# Altium schematic sheet Filter_Protection.SchDoc(Filter_Protection)
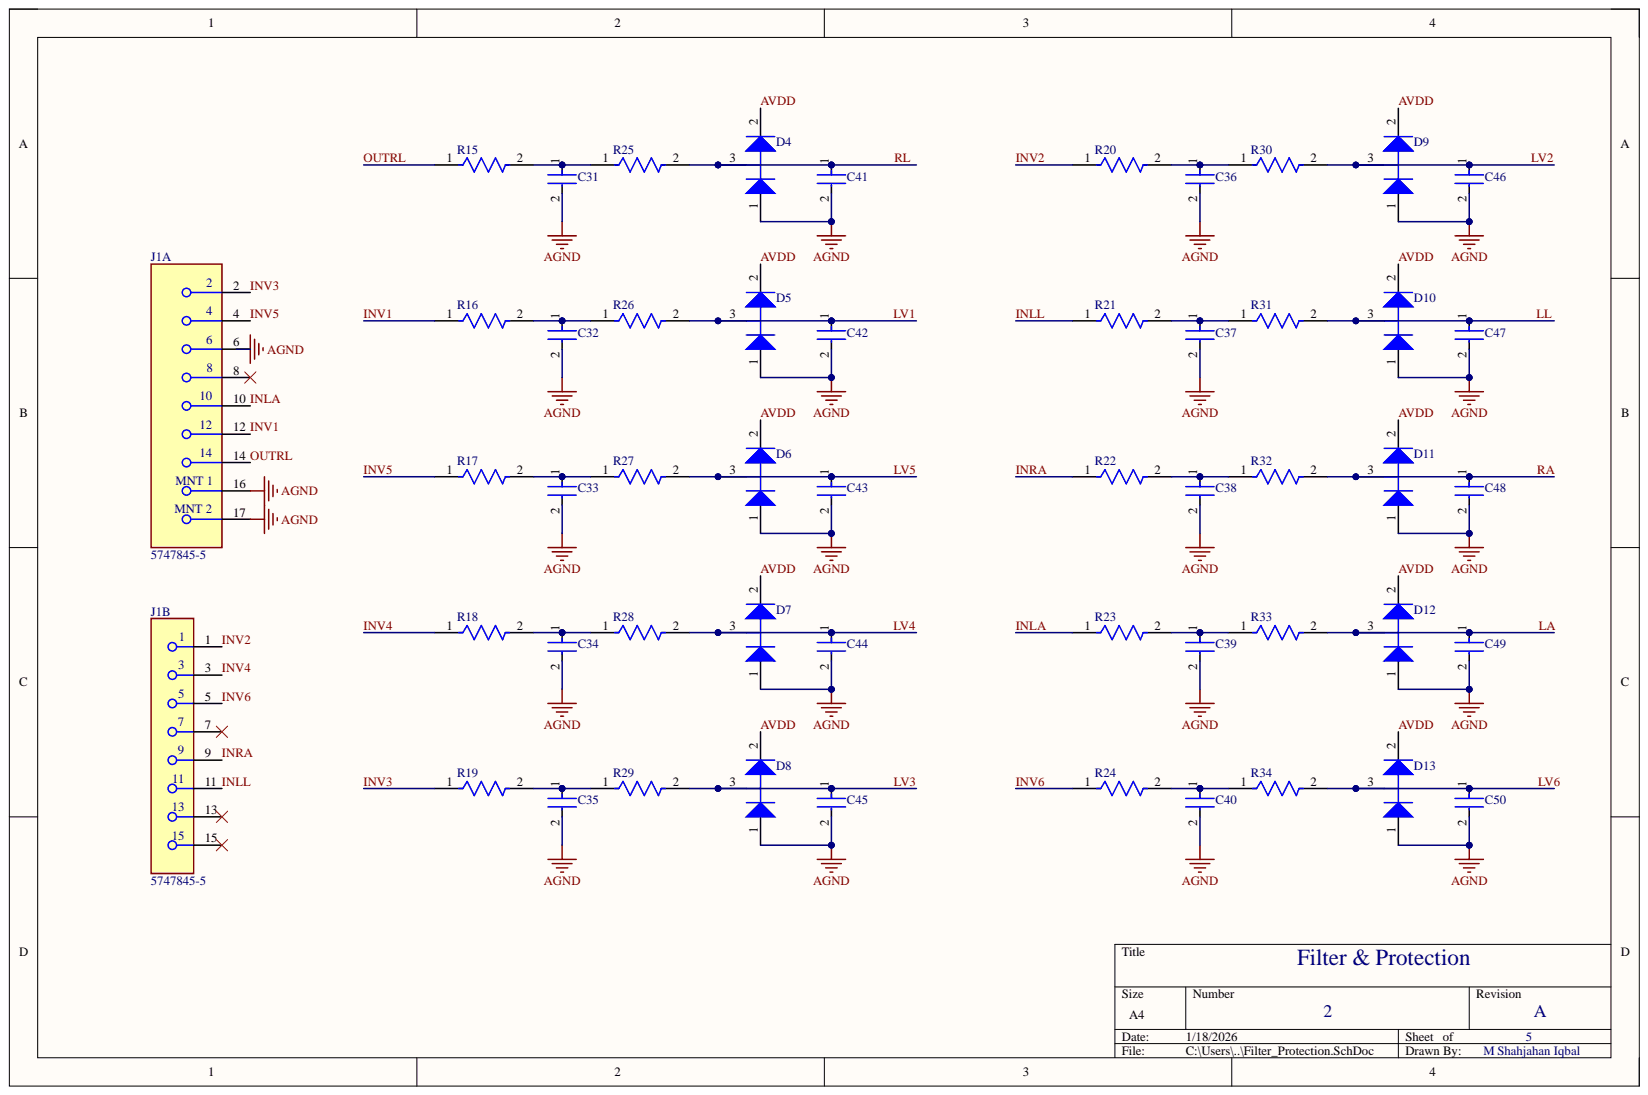
[diagram p0: Filter_Protection.SchDoc]

## Parts
C31  C0603C470K8GACTU  [FP-C0603C-CF-MFG]  (value not drawn)
C32  C0603C470K8GACTU  [FP-C0603C-CF-MFG]  (value not drawn)
C33  C0603C470K8GACTU  [FP-C0603C-CF-MFG]  (value not drawn)
C34  C0603C470K8GACTU  [FP-C0603C-CF-MFG]  (value not drawn)
C35  C0603C470K8GACTU  [FP-C0603C-CF-MFG]  (value not drawn)
C36  C0603C470K8GACTU  [FP-C0603C-CF-MFG]  (value not drawn)
C37  C0603C470K8GACTU  [FP-C0603C-CF-MFG]  (value not drawn)
C38  C0603C470K8GACTU  [FP-C0603C-CF-MFG]  (value not drawn)
C39  C0603C470K8GACTU  [FP-C0603C-CF-MFG]  (value not drawn)
C40  C0603C470K8GACTU  [FP-C0603C-CF-MFG]  (value not drawn)
C41  C0603C470K8GACTU  [FP-C0603C-CF-MFG]  (value not drawn)
C42  C0603C470K8GACTU  [FP-C0603C-CF-MFG]  (value not drawn)
C43  C0603C470K8GACTU  [FP-C0603C-CF-MFG]  (value not drawn)
C44  C0603C470K8GACTU  [FP-C0603C-CF-MFG]  (value not drawn)
C45  C0603C470K8GACTU  [FP-C0603C-CF-MFG]  (value not drawn)
C46  C0603C470K8GACTU  [FP-C0603C-CF-MFG]  (value not drawn)
C47  C0603C470K8GACTU  [FP-C0603C-CF-MFG]  (value not drawn)
C48  C0603C470K8GACTU  [FP-C0603C-CF-MFG]  (value not drawn)
C49  C0603C470K8GACTU  [FP-C0603C-CF-MFG]  (value not drawn)
C50  C0603C470K8GACTU  [FP-C0603C-CF-MFG]  (value not drawn)
D4  BAV199LT1G  [ONSC-SOT-23-3-318-08_V]  (value not drawn)
D5  BAV199LT1G  [ONSC-SOT-23-3-318-08_V]  (value not drawn)
D6  BAV199LT1G  [ONSC-SOT-23-3-318-08_V]  (value not drawn)
D7  BAV199LT1G  [ONSC-SOT-23-3-318-08_V]  (value not drawn)
D8  BAV199LT1G  [ONSC-SOT-23-3-318-08_V]  (value not drawn)
D9  BAV199LT1G  [ONSC-SOT-23-3-318-08_V]  (value not drawn)
D10  BAV199LT1G  [ONSC-SOT-23-3-318-08_V]  (value not drawn)
D11  BAV199LT1G  [ONSC-SOT-23-3-318-08_V]  (value not drawn)
D12  BAV199LT1G  [ONSC-SOT-23-3-318-08_V]  (value not drawn)
D13  BAV199LT1G  [ONSC-SOT-23-3-318-08_V]  (value not drawn)
J1  5747845-5  [FP-5747845-5-MFG]  (parts A,B)
R15  AC0603FR-0722K1L  [FP-AC0603-MFG]  (value not drawn)
R16  AC0603FR-0722K1L  [FP-AC0603-MFG]  (value not drawn)
R17  AC0603FR-0722K1L  [FP-AC0603-MFG]  (value not drawn)
R18  AC0603FR-0722K1L  [FP-AC0603-MFG]  (value not drawn)
R19  AC0603FR-0722K1L  [FP-AC0603-MFG]  (value not drawn)
R20  AC0603FR-0722K1L  [FP-AC0603-MFG]  (value not drawn)
R21  AC0603FR-0722K1L  [FP-AC0603-MFG]  (value not drawn)
R22  AC0603FR-0722K1L  [FP-AC0603-MFG]  (value not drawn)
R23  AC0603FR-0722K1L  [FP-AC0603-MFG]  (value not drawn)
R24  AC0603FR-0722K1L  [FP-AC0603-MFG]  (value not drawn)
R25  AC0603FR-1010KL  [FP-AC0603-IPC_B]  (value not drawn)
R26  AC0603FR-1010KL  [FP-AC0603-IPC_B]  (value not drawn)
R27  AC0603FR-1010KL  [FP-AC0603-IPC_B]  (value not drawn)
R28  AC0603FR-1010KL  [FP-AC0603-IPC_B]  (value not drawn)
R29  AC0603FR-1010KL  [FP-AC0603-IPC_B]  (value not drawn)
R30  AC0603FR-1010KL  [FP-AC0603-IPC_B]  (value not drawn)
R31  AC0603FR-1010KL  [FP-AC0603-IPC_B]  (value not drawn)
R32  AC0603FR-1010KL  [FP-AC0603-IPC_B]  (value not drawn)
R33  AC0603FR-1010KL  [FP-AC0603-IPC_B]  (value not drawn)
R34  AC0603FR-1010KL  [FP-AC0603-IPC_B]  (value not drawn)

## Nets
AGND: C31.2 C32.2 C33.2 C34.2 C35.2 C36.2 C37.2 C38.2 C39.2 C40.2 C41.2 C42.2 C43.2 C44.2 C45.2 C46.2 C47.2 C48.2 C49.2 C50.2 D4.1 D5.1 D6.1 D7.1 D8.1 D9.1 D10.1 D11.1 D12.1 D13.1 J1.6 J1.16 J1.17
AVDD: D4.2 D5.2 D6.2 D7.2 D8.2 D9.2 D10.2 D11.2 D12.2 D13.2
INLA: J1.10 R23.1
INLL: J1.11 R21.1
INRA: J1.9 R22.1
INV1: J1.12 R16.1
INV2: J1.1 R20.1
INV3: J1.2 R19.1
INV4: J1.3 R18.1
INV5: J1.4 R17.1
INV6: J1.5 R24.1
LA: C49.1 D12.3 R33.2
LL: C47.1 D10.3 R31.2
LV1: C42.1 D5.3 R26.2
LV2: C46.1 D9.3 R30.2
LV3: C45.1 D8.3 R29.2
LV4: C44.1 D7.3 R28.2
LV5: C43.1 D6.3 R27.2
LV6: C50.1 D13.3 R34.2
OUTRL: J1.14 R15.1
RA: C48.1 D11.3 R32.2
RL: C41.1 D4.3 R25.2
NetC31_1: C31.1 R15.2 R25.1
NetC32_1: C32.1 R16.2 R26.1
NetC33_1: C33.1 R17.2 R27.1
NetC34_1: C34.1 R18.2 R28.1
NetC35_1: C35.1 R19.2 R29.1
NetC36_1: C36.1 R20.2 R30.1
NetC37_1: C37.1 R21.2 R31.1
NetC38_1: C38.1 R22.2 R32.1
NetC39_1: C39.1 R23.2 R33.1
NetC40_1: C40.1 R24.2 R34.1

Not connected: J1 7 8 13 15

## Library data of the parts
C31-C50 = CMP-1035-01047-3: CAP CER 47PF 10V NP0 0603; Manufacturer: KEMET; Automotive Grade: Grade 1; Material: Ceramic; Temperature Characteristic: C0G; Temperature Coefficient: 30ppm/°C; Tolerance: 0.1; Automotive: Yes; Capacitance: 47 pF; Category: Cap; Component Kind: Standard; ComponentLink2Description: Datasheet; ComponentLink2URL: https://api.kemet.com/component-edge/download/datasheet/C0603C100J5GACAUTO.pdf; Device Class L1: Passive Components; Device Class L2: Capacitors; Device Class L3: Ceramic Capacitors; Digikey Description: CAP CER 47PF 10V NP0 0603; Height: 0.87mm; Lead Free: Yes; Library Name: Altium Content Vault; Manufacturer Part Number: C0603C470K8GACTU; Mouser Description: Capacitor, Ceramic, 47pF, 10VDC, 10-% Tol, 10+% Tol, C0G-TC Code, -30, 30ppm-TC; Octopart: https://octopart.com/c0603c470k8gactu-kemet-10683076; Package: C0603C-CF; RoHS: TRUE; Temperature: -55°C to +125°C; Temperature Range High: +125°C; Temperature Range Low: -55°C; Value: 47 pF; Voltage Rating: 10 V
D4-D13 = CMP-1054-00087-1: Dual Series Switching Diodes, 3-Pin SOT-23, Pb-Free, Tape and Reel; Manufacturer: On Semiconductor; IF Max (A): 0.215; trr Max (ns): 3000; Component Kind: Standard; ComponentLink1Description: Manufacturer URL; ComponentLink1URL: http://www.onsemi.com/; ComponentLink2Description: Datasheet; ComponentLink2URL: http://www.onsemi.com/pub_link/Collateral/BAV199LT1-D.PDF; ComponentLink3Description: Package Specification; ComponentLink3URL: http://www.onsemi.cn/pub_link/Collateral/318-08.PDF; Configuration: Dual Series; DatasheetVersion: Rev. 8; Library Name: Altium Content Vault; Mounting Technology: Surface Mount; PackageDescription: 3-Pin Small Outline Transistor, Body 2.92 x 1.3 mm, Pitch 0.95 mm; PackageReference: SOT-23-3-318-08; PackageVersion: Rev. AP, 11/2009; Packing: Tape and Reel; PartNumber: BAV199LT1G; RoHS: TRUE
J1 = CMP-03367-000001-1: CONN D-SUB RCPT 15POS R/A SOLDER; Manufacturer: TE Connectivity; Conn Gender: Female; Conn Orientation: Right Angle; Current Rating: 6A; Is Female: yes; Number Of Contacts: 15; Pitch: 2.74mm; Shielding: true; Automotive: No; Category: Conn; Component Kind: Standard; ComponentLink2Description: Datasheet; ComponentLink2URL: https://www.te.com/commerce/DocumentDelivery/DDEController?Action=showdoc&DocId=Catalog+Section%7F82068_AMPLIMITE_Right-Angle_Posted_Conn%7F0412%7Fpdf%7FEnglish%7FENG_CS_82068_AMPLIMITE_Right-Angle_Posted_Conn_0412.pdf%7F5747845-5; Contact Material: Gold,Nickel; Device Class L1: Connectors; Device Class L2: D-Sub Connectors; Device Class L3: unset; Digikey Description: CONN D-SUB RCPT 15POS R/A SOLDER; Footprint Url: https://www.te.com/commerce/DocumentDelivery/DDEController?Action=showdoc&DocId=Customer+Drawing%7F5747845%7FA2%7Fpdf%7FEnglish%7FENG_CD_5747845_A2.pdf%7F5747845-5; Height: 0.509inch; Lead Free: Yes; Library Name: Altium Content Vault; Manufacturer Part Number: 5747845-5; Mouser Description: D-Sub Standard Connectors RECP FRNT MTL SHL 15; Octopart: https://octopart.com/5747845-5-te+connectivity-39499908; Package: 5747845-5; RoHS: TRUE; Temperature: -55°C to +105°C; Temperature Range High: +105°C; Temperature Range Low: -55°C
R15-R24 = CMP-03412-024338-2: RES 22.1KΩ 1.00% 0.1W 0603; Max Power Dissipation: 100mW; Resistance: 22.1kR; Temperature Coefficient: 100ppm/°C; Tolerance: 1%; Voltage Rating: 50V; Case Code (Imperial): 0603; Case Code (Metric): 1608; Case/Package: 0603; Component Kind: Standard; Features: Moisture Resistant; Height: 0.022inch; Lead Free: Lead Free; Length: 0.063inch; Library Name: Altium Content Vault; Max Operating Temperature: 155°C; Min Operating Temperature: -55°C; Number of Terminations: 2; Packaging: Tape and Reel; Power Rating: 100mW; Resistor Type: Thick Film; RoHS Compliant: Yes; Series: AC; Termination: SMD/SMT; Weight: 7.1E-05oz; Width: 0.8mm
R25-R34 = CMP-03412-017743-2: RES 10KΩ 1.00% 0.1W 0603; Power Rating: 100mW; Resistance: 10kR; Temperature Coefficient: 100ppm/°C; Tolerance: 1%; Voltage Rating: 50V; Case Code (Imperial): 0603; Case Code (Metric): 1608; Case/Package: 0603; Component Kind: Standard; Height: 0.45mm; Length: 1.6mm; Library Name: Altium Content Vault; Max Operating Temperature: 155°C; Min Operating Temperature: -55°C; Packaging: Tape and Reel; RoHS Compliant: Yes; Series: AC; Termination: SMD/SMT; Weight: 7.1E-05oz; Width: 0.8mm
